# Altium schematic sheet [03] - 28PINS SCHEMATIC.SchDoc("[03] - 28PINS SCHEMATIC") (continued)

## Library data of the parts
C1 C2 = 875105242010: WCAP-PSLP Aluminum Polymer Capacitors; Manufacturer: Wurth Electronics; Dissipation Factor: 8%; Leakage Current: 300uA; Material: 4242ConductorMaterial; Pitch: 5.3mm; Voltage Rating (DC): 10V; Category: Polymer Capacitors; Component Kind: Standard; ComponentLink1Description: http://www.farnell.com/datasheets/1880491.pdf?src-supplier=Farnell; ComponentLink1URL: http://www.farnell.com/datasheets/1880491.pdf?src-supplier=Farnell; ComponentLink2Description: http://katalog.we-online.de/pbs/datasheet/875105242010.pdf?src-supplier=Digikey; ComponentLink2URL: http://katalog.we-online.de/pbs/datasheet/875105242010.pdf?src-supplier=Digikey; ComponentLink3Description: https://katalog.we-online.de/pbs/datasheet/875105242010.pdf?src-supplier=Digikey; ComponentLink3URL: https://katalog.we-online.de/pbs/datasheet/875105242010.pdf?src-supplier=Digikey; ComponentLink4Description: http://www.farnell.com/datasheets/1880491.pdf?src-supplier=Newark; ComponentLink4URL: http://www.farnell.com/datasheets/1880491.pdf?src-supplier=Newark; ComponentLink5Description: http://www.farnell.com/datasheets/1880491.pdf?src-supplier=Element14; ComponentLink5URL: http://www.farnell.com/datasheets/1880491.pdf?src-supplier=Element14; Diameter: 5mm; Height: 5.5mm; Length: 5.5mm; Library Name: Schlib1.SchLib; Manufacturer Part Number: 875105242010; Pricing 1: 1=0.54, 50=0.4572, 100=0.3969, 250=0.3528, 500=0.3087 (USD); RoHS: Yes; Stock 1: 10012; Supplier 1: Digikey; Supplier Part Number 1: 732-6410-1-ND
C3 C12 C13 C19 C21 C22 C34 = CL21A106KOQNNNE: Multilayer Ceramic Capacitor, 10 uF, 16 V, ± 10%, X5R, 0805 [2012 Metric]; Manufacturer: Samsung; Dielectric: X7R; Value: 10uF; Voltage: 16V; Case Code (Imperial): 0805; Case Code (Metric): 2012; Case/Package: 0805; Category: Ceramic Capacitors; Component Kind: Standard; ComponentLink1Description: https://datasheet.ciiva.com/25798/cl21a106koqnnne-25798133.pdf?src-supplier=Digikey; ComponentLink1URL: https://datasheet.ciiva.com/25798/cl21a106koqnnne-25798133.pdf?src-supplier=Digikey; ComponentLink2Description: https://datasheet.ciiva.com/15216/getdatasheetpartid-358435-15216234.pdf?src-supplier=Verical; ComponentLink2URL: https://datasheet.ciiva.com/15216/getdatasheetpartid-358435-15216234.pdf?src-supplier=Verical; ComponentLink3Description: https://datasheet.ciiva.com/16740/cl05b104kq5nnnc-16740697.pdf?src-supplier=Future+Electronics; ComponentLink3URL: https://datasheet.ciiva.com/16740/cl05b104kq5nnnc-16740697.pdf?src-supplier=Future+Electronics; Construction: Flat; Depth: 1.25mm; Height: 1.25mm; Lead Free: Lead Free; Length: 2mm; Library Name: Schlib1.SchLib; Manufacturer Part Number: CL21A106KOQNNNE; Material: Ceramic; Max Operating Temperature: 85°C; Min Operating Temperature: -55°C; Mounting Technology: Surface Mount; Package Quantity: 1; Packaging: Tape and Reel; Pricing 1: 1=0.08, 10=0.027, 50=0.0224, 100=0.0176, 500=0.0164, 1000=0.0152 (USD); Radiation Hardening: No; RoHS: Yes; RoHS Compliant: Yes; Series: CL; Stock 1: 2043437; Supplier 1: Digikey; Supplier Part Number 1: 1276-1096-1-ND; Thickness: 0.055inch; Tolerance: 10%; Voltage Rating: 16V; Voltage Rating (DC): 16V; Width: 0.049inch
C4-C6 C8 C11 C14-C17 C20 C23 C24 C26 C28-C33 = CGA3E2X7R1H104K080AA: Multilayer Ceramic Capacitor, 0.1 uF, 50 V, ï¿½ 10%, X7R, 0603 [1608 Metric]; Manufacturer: TDK; Material: 4241ConductorMaterial; Voltage: 50V; Case Code (Imperial): 0603; Case Code (Metric): 1608; Case/Package: 0603; Category: Ceramic Capacitors; Component Kind: Standard; ComponentLink1Description: https://datasheet.ciiva.com/24216/cga3e2x7r1h104k080aa-266291-24216208.pdf?src-supplier=Mouser; ComponentLink1URL: https://datasheet.ciiva.com/24216/cga3e2x7r1h104k080aa-266291-24216208.pdf?src-supplier=Mouser; ComponentLink2Description: https://datasheet.ciiva.com/15192/getdatasheetpartid-715867-15192412.pdf?src-supplier=Verical; ComponentLink2URL: https://datasheet.ciiva.com/15192/getdatasheetpartid-715867-15192412.pdf?src-supplier=Verical; ComponentLink3Description: https://datasheet.ciiva.com/22725/1735392-22725642.pdf?src-supplier=Element14; ComponentLink3URL: https://datasheet.ciiva.com/22725/1735392-22725642.pdf?src-supplier=Element14; ComponentLink4Description: https://datasheet.ciiva.com/46385/1735392-46385708.pdf?src-supplier=Element14; ComponentLink4URL: https://datasheet.ciiva.com/46385/1735392-46385708.pdf?src-supplier=Element14; ComponentLink5Description: https://datasheet.ciiva.com/23803/1936565-23803084.pdf?src-supplier=Newark; ComponentLink5URL: https://datasheet.ciiva.com/23803/1936565-23803084.pdf?src-supplier=Newark; ComponentLink6Description: https://datasheet.ciiva.com/16500/mlccspec-automotive-general-midvoltage-en-16500693.pdf?src-supplier=Future+Electronics; ComponentLink6URL: https://datasheet.ciiva.com/16500/mlccspec-automotive-general-midvoltage-en-16500693.pdf?src-supplier=Future+Electronics; Depth: 0.8mm; Dielectric: X7R; Height: 0.8mm; Lead Free: 5697LeadFree; Length: 1.6mm; Library Name: Schlib1.SchLib; Manufacturer Part Number: CGA3E2X7R1H104K080AA; Max Operating Temperature: 125°C; Min Operating Temperature: -55°C; Mounting Technology: Surface Mount; Package Quantity: 1; Packaging: Tape and Reel; Pricing 1: 1=0.1, 10=0.048, 50=0.033, 100=0.0284, 500=0.02046, 1000=0.01801 (USD); Radiation Hardening: No; Ratings: AEC-Q200; Reach SVHC Compliant: No; RoHS: Yes; RoHS Compliant: Yes; Series: CGA; Stock 1: 2978211; Supplier 1: Digikey; Supplier Part Number 1: 445-5666-1-ND; Termination: SMD/SMT; Thickness: 0.035inch; Tolerance: 10%; Value: 100nF; Voltage Rating: 50V; Voltage Rating (DC): 50V; Weight: 7.1E-05oz; Width: 0.031inch
C7 = C1005X5R1E105K050BC: Multilayer Ceramic Capacitor, 1 uF, 25 V, ï¿½ 10%, X5R, 0402 [1005 Metric]; Manufacturer: TDK; Dielectric Material: 4241ConductorMaterial; Voltage Rating: 25V; Case Code (Imperial): 0402; Case Code (Metric): 1005; Case/Package: 0402; Category: Ceramic Capacitors; Component Kind: Standard; ComponentLink1Description: https://datasheet.ciiva.com/26936/getdatasheetpartid-756138-26936447.pdf?src-supplier=Verical; ComponentLink1URL: https://datasheet.ciiva.com/26936/getdatasheetpartid-756138-26936447.pdf?src-supplier=Verical; ComponentLink2Description: https://datasheet.ciiva.com/22719/1796654-22719532.pdf?src-supplier=Element14; ComponentLink2URL: https://datasheet.ciiva.com/22719/1796654-22719532.pdf?src-supplier=Element14; Depth: 0.5mm; Dielectric: X5R; Lead Free: 5697LeadFree; Length: 1mm; Library Name: Schlib1.SchLib; Manufacturer Part Number: C1005X5R1E105K050BC; Material: 4241ConductorMaterial; Max Operating Temperature: 85°C; Min Operating Temperature: -55°C; Mounting Technology: Surface Mount; Package Quantity: 1; Packaging: Tape and Reel; Pricing 1: 1=0.1, 10=0.054, 50=0.0374, 100=0.0322, 500=0.0233, 1000=0.02054, 2500=0.01762, 5000=0.01586 (USD); Radiation Hardening: No; Reach SVHC Compliant: No; RoHS: Yes; RoHS Compliant: Yes; Series: C; Stock 1: 435193; Supplier 1: Digikey; Supplier Part Number 1: 445-9066-1-ND; Thickness: 0.022inch; Tolerance: 10%; Value: 1uF; Width: 0.02inch
C9 C10 C25 C27 = C0805C180K5GACTU: Multilayer Ceramic Capacitors MLCC - SMD/SMT 50volts 18pF C0G 10%; Manufacturer: KEMET; Dielectric Material: 4241ConductorMaterial; Temperature Coefficient: 30ppm/°C; Category: Ceramic Capacitors; Component Kind: Standard; ComponentLink1Description: https://datasheet.ciiva.com/5412/kem-c1003-c0g-smd-356956-5412921.pdf?src-supplier=Mouser; ComponentLink1URL: https://datasheet.ciiva.com/5412/kem-c1003-c0g-smd-356956-5412921.pdf?src-supplier=Mouser; ComponentLink2Description: https://datasheet.ciiva.com/26847/getdatasheetpartid-742486-26847863.pdf?src-supplier=Verical; ComponentLink2URL: https://datasheet.ciiva.com/26847/getdatasheetpartid-742486-26847863.pdf?src-supplier=Verical; Lead Free: 5697LeadFree; Library Name: Schlib1.SchLib; Manufacturer Part Number: C0805C180K5GACTU; Material: 4241ConductorMaterial; Pricing 1: 1=0.1, 10=0.035, 50=0.035, 100=0.022, 1000=0.018, 10000=0.012 (USD); RoHS: Yes; Stock 1: 26678; Supplier 1: Mouser; Supplier Part Number 1: 80-C0805C180K5G
D1 = LGL29K-G2J1-24-Z: LED Uni-Color Green 572nm Automotive 2-Pin Chip 0603(1608Metric) T/R; Manufacturer: Osram Opto; Dominant Wavelength: 570nm; Forward Current: 20mA; Forward Voltage: 2.2V; Lens Color: White; Lens Size: 0.8mm; Lens Style: Flat,Diffused,Colorless,Rectangular; Lens Transparency: Diffused; Luminous Flux: 0.012lm; Luminous Intensity: 5.6mcd; Number of Elements: 1; Reverse Voltage: 12V; Test Current: 2mA; Viewing Angle: 160°; Case Code (Imperial): 0603; Case Code (Metric): 1608; Case/Package: 0603; Category: LEDs; Color: Green; Component Kind: Standard; ComponentLink1Description: https://datasheet.ciiva.com/4689/g-l29k-39844-4689584.pdf?src-supplier=Mouser; ComponentLink1URL: https://datasheet.ciiva.com/4689/g-l29k-39844-4689584.pdf?src-supplier=Mouser; ComponentLink2Description: https://datasheet.ciiva.com/2112/00077107-0-2112887.pdf?src-supplier=Digikey; ComponentLink2URL: https://datasheet.ciiva.com/2112/00077107-0-2112887.pdf?src-supplier=Digikey; ComponentLink3Description: https://datasheet.ciiva.com/2112/00077107-0-2112886.pdf?src-supplier=Digikey; ComponentLink3URL: https://datasheet.ciiva.com/2112/00077107-0-2112886.pdf?src-supplier=Digikey; ComponentLink4Description: https://datasheet.ciiva.com/2112/00077107-0-2112888.pdf?src-supplier=Digikey; ComponentLink4URL: https://datasheet.ciiva.com/2112/00077107-0-2112888.pdf?src-supplier=Digikey; Depth: 0.8mm; Height: 0.65mm; Illumination Color: Green; Length: 1.3mm; Library Name: Schlib1.SchLib; Manufacturer Part Number: LGL29K-G2J1-24-Z; Max Operating Temperature: 100°C; Min Operating Temperature: -40°C; Mounting Technology: Surface Mount; Number of LEDs: 1; Package Quantity: 5000; Packaging: Tape and Reel; Pins: 2; Pricing 1: 5000=0.0793, 10000=0.0742, 15000=0.0714, 20000=0.0705, 25000=0.0659, 50000=0.0614 (USD); Radiation Hardening: No; RoHS: Yes; RoHS Compliant: Yes; Series: SMARTLED® 0603; Stock 1: 75000; Supplier 1: Arrow Electronics; Supplier Part Number 1: LGL29K-G2J1-24-Z; Wavelength: 570nm; Width: 0.8mm
D2-D4 = SML-311DTT86: LED Uni-Color Orange 2-Pin Chip 0603(1608Metric) T/R; Manufacturer: Rohm; Category: LEDs; Component Kind: Standard; ComponentLink1Description: https://datasheet.ciiva.com/11774/0900766b80df3a77-11774225.pdf?src-supplier=Rs; ComponentLink1URL: https://datasheet.ciiva.com/11774/0900766b80df3a77-11774225.pdf?src-supplier=Rs; ComponentLink2Description: https://datasheet.ciiva.com/2110/sml-311series-2110838.pdf?src-supplier=Digikey; ComponentLink2URL: https://datasheet.ciiva.com/2110/sml-311series-2110838.pdf?src-supplier=Digikey; ComponentLink3Description: https://datasheet.ciiva.com/2110/sml-311series-2110839.pdf?src-supplier=Digikey; ComponentLink3URL: https://datasheet.ciiva.com/2110/sml-311series-2110839.pdf?src-supplier=Digikey; ComponentLink4Description: https://datasheet.ciiva.com/2110/sml-311series-2110840.pdf?src-supplier=Digikey; ComponentLink4URL: https://datasheet.ciiva.com/2110/sml-311series-2110840.pdf?src-supplier=Digikey; ComponentLink5Description: https://datasheet.ciiva.com/16388/sml-31-16388237.pdf?src-supplier=Future+Electronics; ComponentLink5URL: https://datasheet.ciiva.com/16388/sml-31-16388237.pdf?src-supplier=Future+Electronics; Library Name: Schlib1.SchLib; Manufacturer Part Number: SML-311DTT86; Pricing 1: 1=0.4, 10=0.226, 100=0.1416, 500=0.10852, 1000=0.09839 (USD); RoHS: Yes; Stock 1: 22355; Supplier 1: Digikey; Supplier Part Number 1: 846-SML-311DTT86CT-ND
D5 D6 = BAT54HT1G: Small Signal Schottky Diode, Single, 30 V, 200 Ma, 800 Mv, 600 Ma, 150 C; Manufacturer: ON Semiconductor / Fairchild; Average Rectified Current: 200mA; Breakdown Voltage: 30V; Forward Voltage: 800mV; Max Forward Surge Current (Ifsm): 600mA; Max Reverse Current: 2uA; Polarity: 4901Direction; Power Dissipation: 200mW; Recovery Time: 5ns; Value: 10pF; Category: Schottky Diodes; Component Kind: Standard; ComponentLink1Description: https://datasheet.ciiva.com/4714/bat54ht1-d-72881-4714706.pdf?src-supplier=Mouser; ComponentLink1URL: https://datasheet.ciiva.com/4714/bat54ht1-d-72881-4714706.pdf?src-supplier=Mouser; ComponentLink2Description: https://datasheet.ciiva.com/4452/bat54ht1g-104602-4452889.pdf?src-supplier=Mouser; ComponentLink2URL: https://datasheet.ciiva.com/4452/bat54ht1g-104602-4452889.pdf?src-supplier=Mouser; ComponentLink3Description: https://datasheet.ciiva.com/30479/0900766b811a63a9-30479442.pdf?src-supplier=Rs; ComponentLink3URL: https://datasheet.ciiva.com/30479/0900766b811a63a9-30479442.pdf?src-supplier=Rs; ComponentLink4Description: https://datasheet.ciiva.com/34301/806549-34301562.pdf?src-supplier=Jameco; ComponentLink4URL: https://datasheet.ciiva.com/34301/806549-34301562.pdf?src-supplier=Jameco; ComponentLink5Description: https://datasheet.ciiva.com/1240/1747983-1240762.pdf?src-supplier=Newark; ComponentLink5URL: https://datasheet.ciiva.com/1240/1747983-1240762.pdf?src-supplier=Newark; ComponentLink6Description: https://datasheet.ciiva.com/915/bat54ht1-d-915445.pdf?src-supplier=Digikey; ComponentLink6URL: https://datasheet.ciiva.com/915/bat54ht1-d-915445.pdf?src-supplier=Digikey; ComponentLink7Description: https://datasheet.ciiva.com/915/bat54ht1-d-915444.pdf?src-supplier=Digikey; ComponentLink7URL: https://datasheet.ciiva.com/915/bat54ht1-d-915444.pdf?src-supplier=Digikey; ComponentLink8Description: https://datasheet.ciiva.com/910/bat54ht1g-910499.pdf?src-supplier=Digikey; ComponentLink8URL: https://datasheet.ciiva.com/910/bat54ht1g-910499.pdf?src-supplier=Digikey; ComponentLink9Description: https://datasheet.ciiva.com/910/bat54ht1g-910498.pdf?src-supplier=Digikey; ComponentLink9URL: https://datasheet.ciiva.com/910/bat54ht1g-910498.pdf?src-supplier=Digikey; ComponentLink10Description: https://datasheet.ciiva.com/8294/bat54ht1-d-8294645.pdf?src-supplier=Avnet; ComponentLink10URL: https://datasheet.ciiva.com/8294/bat54ht1-d-8294645.pdf?src-supplier=Avnet; ComponentLink11Description: https://datasheet.ciiva.com/910/bat54ht1g-910497.pdf?src-supplier=Digikey; ComponentLink11URL: https://datasheet.ciiva.com/910/bat54ht1g-910497.pdf?src-supplier=Digikey; ComponentLink12Description: https://datasheet.ciiva.com/8303/bat54ht1g-8303645.pdf?src-supplier=Avnet; ComponentLink12URL: https://datasheet.ciiva.com/8303/bat54ht1g-8303645.pdf?src-supplier=Avnet; ComponentLink13Description: https://datasheet.ciiva.com/915/bat54ht1-d-915443.pdf?src-supplier=Digikey; ComponentLink13URL: https://datasheet.ciiva.com/915/bat54ht1-d-915443.pdf?src-supplier=Digikey; ComponentLink14Description: https://datasheet.ciiva.com/46429/1727620-46429206.pdf?src-supplier=Element14; ComponentLink14URL: https://datasheet.ciiva.com/46429/1727620-46429206.pdf?src-supplier=Element14; ComponentLink15Description: https://datasheet.ciiva.com/1180/bat54ht1-d-1180252.pdf?src-supplier=Element14; ComponentLink15URL: https://datasheet.ciiva.com/1180/bat54ht1-d-1180252.pdf?src-supplier=Element14; ComponentLink16Description: https://datasheet.ciiva.com/1180/bat54ht1-d-1180251.pdf?src-supplier=Element14; ComponentLink16URL: https://datasheet.ciiva.com/1180/bat54ht1-d-1180251.pdf?src-supplier=Element14; ComponentLink17Description: https://datasheet.ciiva.com/17020/bat54ht1g-17020445.pdf?src-supplier=Future+Electronics; ComponentLink17URL: https://datasheet.ciiva.com/17020/bat54ht1g-17020445.pdf?src-supplier=Future+Electronics; ComponentLink18Description: https://datasheet.ciiva.com/48686/001262925-da-01-en-diode-schottky-30v-bat54ht1g-sod-323-fsc-48686266.pdf?src-supplier=Conrad; ComponentLink18URL: https://datasheet.ciiva.com/48686/001262925-da-01-en-diode-schottky-30v-bat54ht1g-sod-323-fsc-48686266.pdf?src-supplier=Conrad; Contact Plating: Tin,Matte; Current Rating: 200mA; Forward Current: 200mA; Height: 1mm; Lead Free: Lead Free; Length: 1.8mm; Library Name: Schlib1.SchLib; Manufacturer Part Number: BAT54HT1G; Max Operating Temperature: 150°C; Max Repetitive Reverse Voltage (Vrrm): 30V; Max Reverse Leakage Current: 2uA; Max Reverse Voltage (DC): 30V; Max Surge Current: 600mA; Min Operating Temperature: -55°C; Mounting Technology: Surface Mount; Output Current: 200mA; Package Quantity: 1; Packaging: Tape and Reel; Peak Non-Repetitive Surge Current: 600mA; Pins: 2; Pricing 1: 3000=0.0119, 12000=0.0119, 30000=0.0119, 75000=0.0119, 120000=0.0119 (USD); Radiation Hardening: No; Reach SVHC Compliant: No; Reverse Recovery Time: 5ns; Reverse Recovery Time-Max: 5ns; Reverse Voltage: 30V; RoHS: Yes; RoHS Compliant: Yes; Series: -; Stock 1: 2241000; Supplier 1: Future Electronics; Supplier Part Number 1: 8184713; Voltage Rating (DC): 30V; Width: 1.35mm
FB1-FB3 = MPZ2012S601AT000: Ferrite Beads Multi-Layer Power 600Ohm 25% 100MHz 2A 100mOhm DCR 0805 T/R; Manufacturer: TDK; Core Material: 228ConductorMaterial; DC Resistance (DCR): 100mR; Impedance: 600R; Number of Lines: 1; Case Code (Imperial): 0805; Case Code (Metric): 2012; Case/Package: 0805; Category: Ferrite Beads and Chips; Component Kind: Standard; ComponentLink1Description: https://datasheet.ciiva.com/46634/beads-commercial-power-mpz-en-46634335.pdf?src-supplier=Digikey; ComponentLink1URL: https://datasheet.ciiva.com/46634/beads-commercial-power-mpz-en-46634335.pdf?src-supplier=Digikey; ComponentLink2Description: https://datasheet.ciiva.com/46634/beads-commercial-power-mpz-en-46634377.pdf?src-supplier=Digikey; ComponentLink2URL: https://datasheet.ciiva.com/46634/beads-commercial-power-mpz-en-46634377.pdf?src-supplier=Digikey; ComponentLink3Description: https://datasheet.ciiva.com/46634/beads-commercial-power-mpz-en-46634606.pdf?src-supplier=Digikey; ComponentLink3URL: https://datasheet.ciiva.com/46634/beads-commercial-power-mpz-en-46634606.pdf?src-supplier=Digikey; Current Rating: 2A; ESR: 100mR; Height: 0.041inch; Lead Free: Lead Free; Length: 0.079inch; Library Name: Schlib1.SchLib; Manufacturer Part Number: MPZ2012S601AT000; Max Current Rating: 2A; Max DC Current: 2A; Max Operating Temperature: 125°C; Min Operating Temperature: -55°C; Mounting Technology: Surface Mount; Package Quantity: 1; Packaging: Tape and Reel; Pricing 1: 1=0.11, 10=0.077, 25=0.0664, 50=0.0594, 100=0.0532, 250=0.0458, 500=0.0407, 1000=0.03605 (USD); Reach SVHC Compliant: No; RMS Current (Irms): 2A; RoHS: Yes; RoHS Compliant: Yes; Series: MPZ; Stock 1: 117452; Supplier 1: Digikey; Supplier Part Number 1: 445-MPZ2012S601AT000CT-ND; Test Frequency: 100MHz; Tolerance: 25%; Width: 0.049inch
FID1-FID4 = FID_1MM: Fiducial 40mil diameter,120mil mask; Library Name: Schlib1.SchLib
J1 J3 = PPTC081LFBN-RC: Female, 8C, Straight, .100"CC; 3.20mm Tail, No Mt. 100u" Tin; PBT, Phos Bronze, 105 C; Manufacturer: Sullins; Category: Connectors; Component Kind: Standard; ComponentLink1Description: https://media.digikey.com/pdf/Data%20Sheets/Sullins%20PDFs/Female_Headers.100_DS.pdf?src-supplier=Digikey; ComponentLink1URL: https://media.digikey.com/pdf/Data%20Sheets/Sullins%20PDFs/Female_Headers.100_DS.pdf?src-supplier=Digikey; ComponentLink2Description: https://datasheet.octopart.com/PPTC081LFBN-RC-Sullins-datasheet-41308905.pdf?src-supplier=Future+Electronics; ComponentLink2URL: https://datasheet.octopart.com/PPTC081LFBN-RC-Sullins-datasheet-41308905.pdf?src-supplier=Future+Electronics; ComponentLink3Description: http://www.sullinscorp.com/catalogs/78_Page80-81_HEADER_.100_2.54mm_FEMALE.pdf?src-supplier=Future+Electronics; ComponentLink3URL: http://www.sullinscorp.com/catalogs/78_Page80-81_HEADER_.100_2.54mm_FEMALE.pdf?src-supplier=Future+Electronics; Contact Material: 150ConductorMaterial; Height: 8.5mm; Housing Material: 4239ConductorMaterial; Lead Free: 5697LeadFree; Length: 20.8mm; Library Name: Schlib1.SchLib; Manufacturer Part Number: PPTC081LFBN-RC; Pricing 1: 1=0.56, 10=0.457, 400=0.32898, 800=0.30935, 1200=0.29843, 5200=0.2925 (USD); RoHS: Yes; Stock 1: 22644; Supplier 1: Digikey; Supplier Part Number 1: S7006-ND; Width: 2.5mm
J2 = PPTC061LFBN-RC: Female, 6 C, Straight, .100"CC; 3.20mm Tail, No Mt. 100u" Tin; PBT, Phos Bronze, 105 C; Manufacturer: Sullins; Category: Connectors; Component Kind: Standard; ComponentLink1Description: https://media.digikey.com/pdf/Data%20Sheets/Sullins%20PDFs/Female_Headers.100_DS.pdf?src-supplier=Digikey; ComponentLink1URL: https://media.digikey.com/pdf/Data%20Sheets/Sullins%20PDFs/Female_Headers.100_DS.pdf?src-supplier=Digikey; ComponentLink2Description: https://datasheet.octopart.com/PPTC061LFBN-RC-Sullins-datasheet-41308905.pdf?src-supplier=Future+Electronics; ComponentLink2URL: https://datasheet.octopart.com/PPTC061LFBN-RC-Sullins-datasheet-41308905.pdf?src-supplier=Future+Electronics; ComponentLink3Description: http://www.sullinscorp.com/catalogs/78_Page80-81_HEADER_.100_2.54mm_FEMALE.pdf?src-supplier=Future+Electronics; ComponentLink3URL: http://www.sullinscorp.com/catalogs/78_Page80-81_HEADER_.100_2.54mm_FEMALE.pdf?src-supplier=Future+Electronics; Library Name: Schlib1.SchLib; Manufacturer Part Number: PPTC061LFBN-RC; Pricing 1: 1=0.45, 10=0.37, 80=0.30788, 440=0.26464, 1000=0.24605, 5000=0.2327 (USD); RoHS: Yes; Stock 1: 17823; Supplier 1: Digikey
J4 = PPTC101LFBN-RC: 10 Position Single Row 2.54 mm Pitch Vertical Through Hole Socket Header; Manufacturer: Sullins; Category: Connectors; Component Kind: Standard; ComponentLink1Description: https://media.digikey.com/pdf/Data%20Sheets/Sullins%20PDFs/Female_Headers.100_DS.pdf?src-supplier=Digikey; ComponentLink1URL: https://media.digikey.com/pdf/Data%20Sheets/Sullins%20PDFs/Female_Headers.100_DS.pdf?src-supplier=Digikey; Library Name: Schlib1.SchLib; Manufacturer Part Number: PPTC101LFBN-RC; Pricing 1: 1=0.62, 10=0.503, 320=0.36947, 640=0.34744, 960=0.33517, 5120=0.325 (USD); RoHS: Yes; Stock 1: 14684; Supplier 1: Digikey; Supplier Part Number 1: S7008-ND
J5 J6 = M20-9980346: 2.54mm (0.1") Pitch DIL Vertical PC Tail Pin Header, 6.1mm mating pin height, tin, 3+3 contacts; Manufacturer: Harwin; Gender: 29Gender; Insulation Resistance: 10GR; Voltage Rating (DC): 750V; Category: Headers and Wire Housings; Component Kind: Standard; ComponentLink1Description: https://datasheet.ciiva.com/26899/getdatasheetpartid-483857-26899433.pdf?src-supplier=Verical; ComponentLink1URL: https://datasheet.ciiva.com/26899/getdatasheetpartid-483857-26899433.pdf?src-supplier=Verical; ComponentLink2Description: https://datasheet.ciiva.com/11745/0900766b81034e61-11745752.pdf?src-supplier=Rs; ComponentLink2URL: https://datasheet.ciiva.com/11745/0900766b81034e61-11745752.pdf?src-supplier=Rs; ComponentLink3Description: https://datasheet.ciiva.com/8046/112195-8046906.pdf?src-supplier=Element14; ComponentLink3URL: https://datasheet.ciiva.com/8046/112195-8046906.pdf?src-supplier=Element14; Library Name: Schlib1.SchLib; Manufacturer Part Number: M20-9980346; Pricing 1: 1=0.24, 10=0.197, 100=0.1606, 500=0.13922, 1000=0.13092, 5000=0.1135, 10000=0.10674 (USD); RoHS: Yes; Stock 1: 6432; Supplier 1: Digikey; Supplier Part Number 1: 952-2121-ND
J7 = 10118193-0001LF: USB - micro B USB 2.0 Receptacle Connector 5 Position Surface Mount, Right Angle, Horizontal; Manufacturer: Amphenol Communications Solutions; Connector Type: 309,4640ConnectorType; Current Rating: 1.8A; Insulation Resistance: 1GR; Max Voltage Rating (AC): 100V; Number of Contacts: 5NUMBER; Number of Ports: 1NUMBER; Pitch: 650um; USB Standard: 6108USBStandard; Category: USB Connectors; Color: 46Colour; Component Kind: Standard; ComponentLink1Description: https://datasheet.octopart.com/10118193-0001LF-Amphenol-Communications-Solutions-datasheet-96019471.pdf?src-supplier=Element14; ComponentLink1URL: https://datasheet.octopart.com/10118193-0001LF-Amphenol-Communications-Solutions-datasheet-96019471.pdf?src-supplier=Element14; ComponentLink2Description: https://datasheet.octopart.com/10118193-0001LF-Amphenol-Communications-Solutions-datasheet-55953155.pdf?src-supplier=TME; ComponentLink2URL: https://datasheet.octopart.com/10118193-0001LF-Amphenol-Communications-Solutions-datasheet-55953155.pdf?src-supplier=TME; ComponentLink3Description: https://datasheet.octopart.com/10118193-0001LF-Amphenol-Communications-Solutions-datasheet-11792339.pdf?src-supplier=Electro+Sonic; ComponentLink3URL: https://datasheet.octopart.com/10118193-0001LF-Amphenol-Communications-Solutions-datasheet-11792339.pdf?src-supplier=Electro+Sonic; Contact Material: 153,157ConductorMaterial; Contact Plating: 157ConductorMaterial; Depth: 5.67mm; Features: 6303FeaturesType; Flammability Rating: 5813Flammability; Height: 3mm; Housing Material: 4242ConductorMaterial; Length: 7.86mm; Library Name: Schlib1.SchLib; Manufacturer Part Number: 10118193-0001LF; Max Operating Temperature: 80°C; Min Operating Temperature: -55°C; Mounting Technology: 8,4608Mount; Orientation: 5492OrientationType; Pins: 11NUMBER; Pricing 1: 1=0.4, 10=0.326, 25=0.3, 50=0.2822, 100=0.2654, 250=0.24464, 500=0.23002 (USD); Radiation Hardening: 5898YesNo; RoHS: No; Stock 1: 168770; Supplier 1: Digikey; Supplier Part Number 1: 609-4616-1-ND; Termination: 4558ConnectorType; Voltage Rating: 100V
J8 J9 = PAD_WITH_HOLE_4MMX1.5MM: pad with a hall 4mmx1.5mm; Library Name: Schlib1.SchLib
JP1 JP4 = M20-9990345: Conn Unshrouded Header HDR 3 POS 2.54mm Solder ST Top Entry Thru-Hole Bag; Manufacturer: Harwin; Contact Resistance: 25mR; Current Rating: 3A; Insulation Resistance: 10GR; Number of Contacts: 3; Voltage Rating (AC): 750V; Case/Package: SIL; Category: Headers and Wire Housings; Color: Black; Component Kind: Standard; ComponentLink1Description: https://datasheet.ciiva.com/11747/0900766b80da602d-11747505.pdf?src-supplier=Rs; ComponentLink1URL: https://datasheet.ciiva.com/11747/0900766b80da602d-11747505.pdf?src-supplier=Rs; ComponentLink2Description: https://datasheet.ciiva.com/5160/m20-999-351179-5160492.pdf?src-supplier=Mouser; ComponentLink2URL: https://datasheet.ciiva.com/5160/m20-999-351179-5160492.pdf?src-supplier=Mouser; ComponentLink3Description: https://datasheet.ciiva.com/10151/m20-999-10151334.pdf?src-supplier=Avnet; ComponentLink3URL: https://datasheet.ciiva.com/10151/m20-999-10151334.pdf?src-supplier=Avnet; Contact Material: Bronze,Brass; Contact Mating Length: 0.24inch; Contact Plating: Gold; Contact Type: Male Pin; Depth: 2.54mm; Housing Color: Black; Housing Material: Nylon; Length: 7.62mm; Library Name: Schlib1.SchLib; Manufacturer Part Number: M20-9990345; Max Current Rating: 3A; Max Operating Temperature: 105°C; Min Operating Temperature: -40°C; Mounting Technology: Through Hole; Number of Positions: 3; Number of Rows: 1; Orientation: Straight; Package Quantity: 1000; Packaging: Bulk; Pitch: 2.54mm; Pricing 1: 1=0.15, 10=0.119, 100=0.0969, 500=0.08394, 1000=0.07893, 5000=0.06843, 10000=0.06435 (USD); Radiation Hardening: No; Reach SVHC Compliant: No; RoHS: Yes; RoHS Compliant: Yes; Series: M20; Stock 1: 90259; Supplier 1: Digikey; Supplier Part Number 1: 952-2263-ND; Termination: Solder; Weight: 0.006138oz
JP2 = HTSW-102-07-G-D: Conn Unshrouded Header HDR 4 POS 2.54mm Solder ST Top Entry Thru-Hole Bag; Manufacturer: Samtec; Gender: Male; Number of Contacts: 4; Pitch: 0.1inch; Category: Headers and Wire Housings; Color: Natural; Component Kind: Standard; ComponentLink1Description: https://datasheet.ciiva.com/737/htsw-xxx-xx-xxx-x-xx-xx-xx-mkt-737892.pdf?src-supplier=Digikey; ComponentLink1URL: https://datasheet.ciiva.com/737/htsw-xxx-xx-xxx-x-xx-xx-xx-mkt-737892.pdf?src-supplier=Digikey; ComponentLink2Description: https://datasheet.ciiva.com/10178/htsw-th-10178927.pdf?src-supplier=Avnet; ComponentLink2URL: https://datasheet.ciiva.com/10178/htsw-th-10178927.pdf?src-supplier=Avnet; Contact Material: Bronze; Contact Mating Length: 0.23inch; Contact Plating: Gold; Contact Type: Male Pin; Library Name: Schlib1.SchLib; Manufacturer Part Number: HTSW-102-07-G-D; Mounting Technology: Through Hole; Number of Positions: 4; Number of Rows: 2; Package Quantity: 1; Packaging: Bulk; Pricing 1: 1=0.27, 10=0.22, 100=0.1789, 500=0.15506, 1000=0.1458, 5000=0.12641, 10000=0.11888 (USD); Radiation Hardening: No; Reach SVHC Compliant: No; RoHS: Yes; RoHS Compliant: Yes; Row Spacing: 0.1inch; Series: HTSW; Stock 1: 776; Supplier 1: Digikey; Supplier Part Number 1: SAM10533-ND; Termination: Solder
JP3 = M20-9770446: Conn Unshrouded Header HDR 4 POS 2.54mm Solder ST Thru-Hole Bulk/Loose; Manufacturer: Harwin; Current Rating: 3A; Gender: Male; Mated Stacking Heights: 16.54mm; Number of Contacts: 4; Voltage Rating: 750V; Case/Package: SIL; Category: Headers and Wire Housings; Component Kind: Standard; ComponentLink1Description: https://datasheet.ciiva.com/6832/m20-977-6832936.pdf?src-supplier=Digikey; ComponentLink1URL: https://datasheet.ciiva.com/6832/m20-977-6832936.pdf?src-supplier=Digikey; Contact Finish - Mating: TIN; Contact Material: Brass; Contact Plating: Tin; Contact Shape: Square; Contact Type: Male Pin; Fastening Type: Pull; Flammability Rating: UL94 V-0; Housing Material: Nylon; Insulation Color: Black; Insulation Material: Nylon; Library Name: Schlib1.SchLib; Manufacturer Part Number: M20-9770446; Max Operating Temperature: 105°C; Min Operating Temperature: -40°C; Mounting Technology: Through Hole; Number of Positions: 4; Number of Rows: 1; Package Quantity: 1000; Packaging: Bulk; Pitch: 2.54mm; Pricing 1: 1=0.17, 10=0.14, 100=0.114, 500=0.09884, 1000=0.09294, 5000=0.08058, 10000=0.07578 (USD); RoHS: Yes; RoHS Compliant: Yes; Series: M20; Stock 1: 9094; Supplier 1: Digikey; Supplier Part Number 1: 952-1785-ND; Termination: Solder
L1 = CIGT252008LM2R2MNE: ROHS3Compliant Thin Film Active WRAPAROUND Fixed Inductor -40C~125C 1MHz 97mOhm Max 2.2muH; Manufacturer: Samsung; Core Material: Metal,Composite; DC Resistance (DCR): 97mR; Saturation Current: 2.1A; Shielding: Unshielded; Tolerance: 20%; Value: 2.2uH; Case Code (Imperial): 1008; Case Code (Metric): 2520; Case/Package: 1008; Category: Fixed Inductors; Component Kind: Standard; ComponentLink1Description: http://www.samsungsem.com/kr/support/product-search/inductor/CIGT252008LM2R2MNE.jsp?src-supplier=Digikey; ComponentLink1URL: http://www.samsungsem.com/kr/support/product-search/inductor/CIGT252008LM2R2MNE.jsp?src-supplier=Digikey; Library Name: Schlib1.SchLib; Manufacturer Part Number: CIGT252008LM2R2MNE; Max Operating Temperature: 125°C; Min Operating Temperature: -40°C; Mounting Technology: Surface Mount; Packaging: Cut Tape; Pricing 1: 1=0.23, 10=0.176, 25=0.1592, 50=0.1476, 100=0.1368, 250=0.12368, 500=0.11458, 1000=0.10614 (USD); RoHS: Yes; RoHS Compliant: Yes; Series: CIGT; Stock 1: 197003; Supplier 1: Digikey; Supplier Part Number 1: 1276-6925-1-ND; Test Frequency: 1MHz
LINK1-LINK3 = SPC02SXCN-RC: 2 C, Open Top, .100" CC; No Mounting, 105 C, PBT; Phos Bronze, Gold Flash; Manufacturer: Sullins; Category: Connectors; ComponentLink1Description: https://datasheet.ciiva.com/767/285-sxcn-11508-767873.pdf?src-supplier=Digikey; ComponentLink1URL: https://datasheet.ciiva.com/767/285-sxcn-11508-767873.pdf?src-supplier=Digikey; Library Name: Schlib1.SchLib; Manufacturer Part Number: SPC02SXCN-RC; Pricing 1: 1=0.1, 10=0.059, 25=0.0544, 50=0.0512, 100=0.0481, 250=0.04432, 500=0.04168, 1000=0.03919, 3000=0.03555 (USD); RoHS: Yes; Stock 1: 37862; Supplier 1: Digikey; Supplier Part Number 1: S9342-ND
MH1-MH3 = MH_7.4MMC_3.2MMD: mounting hall 7.4mm diameter 3.2mm drill; Library Name: Schlib1.SchLib
MH4 = MH_7.4MMNC_3.2MMD: hole 7.4 mm diameter (non copper) 3.2mm drill npth; Library Name: Schlib1.SchLib
R1 = RC0805FR-07100KL: SMD Chip Resistor, 100 kOhm, ï¿½ 1%, 125 mW, 0805 [2012 Metric], Thick Film, General Purpose; Manufacturer: Yageo; Max Power Dissipation: 125mW; Temperature Coefficient: 100ppm/°C; Value: 100kR; Voltage Rating: 150V; Case Code (Imperial): 0805; Case Code (Metric): 2012; Case/Package: 0805; Category: Chip SMD Resistors; Component Kind: Standard; ComponentLink1Description: https://datasheet.ciiva.com/11808/0900766b8106beab-11808858.pdf?src-supplier=Rs; ComponentLink1URL: https://datasheet.ciiva.com/11808/0900766b8106beab-11808858.pdf?src-supplier=Rs; ComponentLink2Description: https://datasheet.ciiva.com/7941/1686128-7941677.pdf?src-supplier=Element14; ComponentLink2URL: https://datasheet.ciiva.com/7941/1686128-7941677.pdf?src-supplier=Element14; ComponentLink3Description: https://datasheet.ciiva.com/7660/38627-7660796.pdf?src-supplier=Element14; ComponentLink3URL: https://datasheet.ciiva.com/7660/38627-7660796.pdf?src-supplier=Element14; ComponentLink4Description: https://datasheet.ciiva.com/22747/444164-22747534.pdf?src-supplier=Element14; ComponentLink4URL: https://datasheet.ciiva.com/22747/444164-22747534.pdf?src-supplier=Element14; ComponentLink5Description: https://datasheet.ciiva.com/2457/pyu-r-int-thick-7-2457018.pdf?src-supplier=Digikey; ComponentLink5URL: https://datasheet.ciiva.com/2457/pyu-r-int-thick-7-2457018.pdf?src-supplier=Digikey; ComponentLink6Description: https://datasheet.ciiva.com/2457/pyu-r-int-thick-7-2457019.pdf?src-supplier=Digikey; ComponentLink6URL: https://datasheet.ciiva.com/2457/pyu-r-int-thick-7-2457019.pdf?src-supplier=Digikey; ComponentLink7Description: https://datasheet.ciiva.com/2457/pyu-r-int-thick-7-2457017.pdf?src-supplier=Digikey; ComponentLink7URL: https://datasheet.ciiva.com/2457/pyu-r-int-thick-7-2457017.pdf?src-supplier=Digikey; ComponentLink8Description: https://datasheet.ciiva.com/12667/rc0805-12667001.pdf?src-supplier=Mouser; ComponentLink8URL: https://datasheet.ciiva.com/12667/rc0805-12667001.pdf?src-supplier=Mouser; ComponentLink9Description: https://datasheet.ciiva.com/7941/1697719-7941676.pdf?src-supplier=Element14; ComponentLink9URL: https://datasheet.ciiva.com/7941/1697719-7941676.pdf?src-supplier=Element14; ComponentLink10Description: https://datasheet.ciiva.com/7941/1697719-7941678.pdf?src-supplier=Element14; ComponentLink10URL: https://datasheet.ciiva.com/7941/1697719-7941678.pdf?src-supplier=Element14; ComponentLink11Description: https://datasheet.ciiva.com/16747/rc0805-16747226.pdf?src-supplier=Future+Electronics; ComponentLink11URL: https://datasheet.ciiva.com/16747/rc0805-16747226.pdf?src-supplier=Future+Electronics; Construction: Rectangular; Depth: 1.25mm; Features: Moisture Resistant; Height: 0.024inch; Lead Free: 5697LeadFree; Lead Pitch: 0.4mm; Length: 0.079inch; Library Name: Schlib1.SchLib; Manufacturer Part Number: RC0805FR-07100KL; Max Operating Temperature: 155°C; Military Standard: Not; Min Operating Temperature: -55°C; Number of Terminations: 2; Package Quantity: 1; Packaging: Tape and Reel; Pins: 2; Power Rating: 125mW; Pricing 1: 5000=0.0017 (USD); Reach SVHC Compliant: Unknown; Resistance Tolerance: 1%; Resistor Type: Thick Film; RoHS: Yes; RoHS Compliant: Yes; Series: RC; Stock 1: 3175000; Supplier 1: Future Electronics; Supplier Part Number 1: 2952521; Technology: THICK FILM; Termination: SMD/SMT; Tolerance: 1%; Voltage Rating (AC): 150V; Voltage Rating (DC): 150V; Width: 0.049inch
R2 R3 R15 R17 R22 = RC0603FR-07560RL: SMD Chip Resistor, 560 Ohm, ï¿½ 1%, 100 mW, 0603 [1608 Metric], Thick Film, General Purpose; Manufacturer: Yageo; Max Power Dissipation: 100mW; Temperature Coefficient: 100ppm/°C; Value: 560R; Voltage Rating: 75V; Voltage Rating (AC): 50V; Case Code (Imperial): 0603; Case Code (Metric): 1608; Case/Package: 0603; Category: Chip SMD Resistors; Component Kind: Standard; ComponentLink1Description: https://datasheet.ciiva.com/11808/0900766b80f96399-11808127.pdf?src-supplier=Rs; ComponentLink1URL: https://datasheet.ciiva.com/11808/0900766b80f96399-11808127.pdf?src-supplier=Rs; ComponentLink2Description: https://datasheet.ciiva.com/46412/443971-46412853.pdf?src-supplier=Element14; ComponentLink2URL: https://datasheet.ciiva.com/46412/443971-46412853.pdf?src-supplier=Element14; ComponentLink3Description: https://datasheet.ciiva.com/2454/pyu-r-int-thick-7-2454382.pdf?src-supplier=Digikey; ComponentLink3URL: https://datasheet.ciiva.com/2454/pyu-r-int-thick-7-2454382.pdf?src-supplier=Digikey; ComponentLink4Description: https://datasheet.ciiva.com/2454/pyu-r-int-thick-7-2454383.pdf?src-supplier=Digikey; ComponentLink4URL: https://datasheet.ciiva.com/2454/pyu-r-int-thick-7-2454383.pdf?src-supplier=Digikey; ComponentLink5Description: https://datasheet.ciiva.com/2454/pyu-r-int-thick-7-2454381.pdf?src-supplier=Digikey; ComponentLink5URL: https://datasheet.ciiva.com/2454/pyu-r-int-thick-7-2454381.pdf?src-supplier=Digikey; ComponentLink6Description: https://datasheet.ciiva.com/7746/443971-7746374.pdf?src-supplier=Element14; ComponentLink6URL: https://datasheet.ciiva.com/7746/443971-7746374.pdf?src-supplier=Element14; ComponentLink7Description: https://datasheet.ciiva.com/7746/443971-7746375.pdf?src-supplier=Element14; ComponentLink7URL: https://datasheet.ciiva.com/7746/443971-7746375.pdf?src-supplier=Element14; Construction: Rectangular; Depth: 0.8mm; Features: Moisture Resistant; Height: 0.45mm; Lead Free: Lead Free; Length: 1.6mm; Library Name: Schlib1.SchLib; Manufacturer Part Number: RC0603FR-07560RL; Max Operating Temperature: 155°C; Military Standard: Not; Min Operating Temperature: -55°C; Number of Terminations: 2; Package Quantity: 1; Packaging: Tape and Reel; Pins: 2; Power Rating: 100mW; Pricing 1: 5000=0.001 (USD); Reach SVHC Compliant: No; Resistance Tolerance: 1%; Resistor Type: Thick Film; RoHS: Yes; RoHS Compliant: Yes; Series: RC; Stock 1: 335000; Supplier 1: Future Electronics; Supplier Part Number 1: 3410891; Technology: THICK FILM; Termination: SMD/SMT; Tolerance: 1%; Voltage Rating (DC): 50V; Weight: 7.1E-05oz; Width: 0.8mm
R4 R14 R18-R21 = RT0805FRE0710KL: Fixed Resistor, Thin Film, 0.125W, 10000ohm, 150V, 1% +/-Tol, 50ppm/Cel, Surface Mount, 0805; Manufacturer: Yageo; Category: Chip SMD Resistors; Component Kind: Standard; ComponentLink1Description: https://datasheet.ciiva.com/12834/pyu-rt-1-to-0-05-rohs-l-4-12834887.pdf?src-supplier=Mouser; ComponentLink1URL: https://datasheet.ciiva.com/12834/pyu-rt-1-to-0-05-rohs-l-4-12834887.pdf?src-supplier=Mouser; ComponentLink2Description: https://datasheet.ciiva.com/7942/1392914-7942071.pdf?src-supplier=Element14; ComponentLink2URL: https://datasheet.ciiva.com/7942/1392914-7942071.pdf?src-supplier=Element14; ComponentLink3Description: https://datasheet.ciiva.com/7942/1392914-7942072.pdf?src-supplier=Element14; ComponentLink3URL: https://datasheet.ciiva.com/7942/1392914-7942072.pdf?src-supplier=Element14; ComponentLink4Description: https://datasheet.ciiva.com/25647/pyu-rt-1-to-0-05-rohs-l-4-25647309.pdf?src-supplier=Digikey; ComponentLink4URL: https://datasheet.ciiva.com/25647/pyu-rt-1-to-0-05-rohs-l-4-25647309.pdf?src-supplier=Digikey; ComponentLink5Description: https://datasheet.ciiva.com/29711/pyu-rt-1-to-0-05-rohs-l-4-29711644.pdf?src-supplier=Digikey; ComponentLink5URL: https://datasheet.ciiva.com/29711/pyu-rt-1-to-0-05-rohs-l-4-29711644.pdf?src-supplier=Digikey; ComponentLink6Description: https://datasheet.ciiva.com/29711/pyu-rt-1-to-0-05-rohs-l-4-29711645.pdf?src-supplier=Digikey; ComponentLink6URL: https://datasheet.ciiva.com/29711/pyu-rt-1-to-0-05-rohs-l-4-29711645.pdf?src-supplier=Digikey; Lead Free: 5697LeadFree; Library Name: Schlib1.SchLib; Manufacturer Part Number: RT0805FRE0710KL; Pricing 1: 1=0.1, 10=0.029, 50=0.0244, 100=0.0204, 500=0.0199, 1000=0.01938 (USD); RoHS: Yes; Stock 1: 59694; Supplier 1: Digikey; Supplier Part Number 1: YAG1239CT-ND; Voltage Rating (DC): 150V
R5-R7 R13 R23-R25 = RC0402FR-0720RL: SMD Chip Resistor, 20 Ohm, ± 1%, 62.5 mW, 0402 [1005 Metric], Thick Film, General Purpose; Manufacturer: Yageo; Max Power Dissipation: 63mW; Power Rating: 62.5mW; Temperature Coefficient: 100ppm/°C; Value: 20R; Voltage Rating: 50V; Case Code (Imperial): 0402; Case Code (Metric): 1005; Case/Package: 0402; Category: Chip SMD Resistors; Component Kind: Standard; ComponentLink1Description: https://datasheet.ciiva.com/5998/1018594-5998583.pdf?src-supplier=Farnell; ComponentLink1URL: https://datasheet.ciiva.com/5998/1018594-5998583.pdf?src-supplier=Farnell; ComponentLink2Description: https://datasheet.ciiva.com/7849/1018594-7849902.pdf?src-supplier=Element14; ComponentLink2URL: https://datasheet.ciiva.com/7849/1018594-7849902.pdf?src-supplier=Element14; ComponentLink3Description: https://datasheet.ciiva.com/5998/1018594-5998584.pdf?src-supplier=Farnell; ComponentLink3URL: https://datasheet.ciiva.com/5998/1018594-5998584.pdf?src-supplier=Farnell; ComponentLink4Description: https://datasheet.ciiva.com/7849/1018594-7849903.pdf?src-supplier=Element14; ComponentLink4URL: https://datasheet.ciiva.com/7849/1018594-7849903.pdf?src-supplier=Element14; ComponentLink5Description: https://datasheet.ciiva.com/7983/1018594-7983513.pdf?src-supplier=Element14; ComponentLink5URL: https://datasheet.ciiva.com/7983/1018594-7983513.pdf?src-supplier=Element14; ComponentLink6Description: https://datasheet.ciiva.com/8890/pyu-rc0402-51-rohs-l-6-8890328.pdf?src-supplier=Avnet; ComponentLink6URL: https://datasheet.ciiva.com/8890/pyu-rc0402-51-rohs-l-6-8890328.pdf?src-supplier=Avnet; ComponentLink7Description: https://datasheet.ciiva.com/16741/pyu-rc0402-51-rohs-l-6-r-16741885.pdf?src-supplier=Future+Electronics; ComponentLink7URL: https://datasheet.ciiva.com/16741/pyu-rc0402-51-rohs-l-6-r-16741885.pdf?src-supplier=Future+Electronics; Construction: Rectangular; Contact Plating: Tin,Matte; Depth: 0.5mm; Features: Moisture Resistant; Height: 0.35mm; Lead Free: Lead Free; Length: 1mm; Library Name: Schlib1.SchLib; Manufacturer Part Number: RC0402FR-0720RL; Max Operating Temperature: 155°C; Military Standard: Not; Min Operating Temperature: -55°C; Number of Terminations: 2; Packaging: Tape and Reel; Pins: 2; Pricing 1: 10000=0.0006 (USD); Reach SVHC Compliant: No; Resistance Tolerance: 1%; Resistor Type: Thick Film; RoHS: Yes; RoHS Compliant: Yes; Series: RC; Stock 1: 620000; Supplier 1: Future Electronics; Supplier Part Number 1: 8738049; Technology: THICK FILM; Termination: SMD/SMT; Tolerance: 1%; Voltage Rating (DC): 50V; Width: 0.5mm; Working Voltage: 50V
R8 R26-R28 = RC0603JR-070RL: GENERAL PURPOSE CHIP RESISTOR Metal Glaze/thick Film, 0.1W, 0ohm, Surface Mount, 0603; Manufacturer: Yageo; Max Power Dissipation: 100mW; Resistance Tolerance: 5%; Temperature Coefficient: 200ppm/°C; Tolerance: 1%; Value: 0mR; Voltage Rating: 50V; Case Code (Imperial): 0603; Case Code (Metric): 1608; Case/Package: 0603; Category: Chip SMD Resistors; Component Kind: Standard; Construction: Rectangular; Contact Plating: Tin,Matte; Depth: 0.8mm; Features: Moisture Resistant; Height: 0.45mm; Lead Free: 5697LeadFree; Length: 1.6mm; Library Name: Schlib1.SchLib; Manufacturer Part Number: RC0603JR-070RL; Max Operating Temperature: 155°C; Military Standard: Not; Min Operating Temperature: -55°C; Number of Terminations: 2; Package Quantity: 5000; Packaging: Tape and Reel; Pins: 2; Power Rating: 100mW; Pricing 1: 5000=0.001 (USD); Reach SVHC Compliant: Unknown; Resistor Type: Thick Film; RoHS: Yes; RoHS Compliant: Yes; Series: RC; Stock 1: 7115000; Supplier 1: Future Electronics; Supplier Part Number 1: 3992146; Technology: THICK FILM; Termination: SMD/SMT; Voltage Rating (DC): 50V; Width: 0.8mm
R9 R16 = 26-61-4040: 3.96mm (.156") Pitch KK® Solid Header, Vertical, Friction Lock, 4 Circuits, Gold (Au) Plating; Manufacturer: Molex; Gender: 29Gender; Glow Wire Compliant: 16Compliance; Insulation Resistance: 50GR; Number of Circuits: 3NUMBER; Voltage Rating (DC): 250V; Category: Headers and Wire Housings; Component Kind: Standard; ComponentLink1Description: https://datasheet.ciiva.com/11749/0900766b80d6bf9b-11749077.pdf?src-supplier=Rs; ComponentLink1URL: https://datasheet.ciiva.com/11749/0900766b80d6bf9b-11749077.pdf?src-supplier=Rs; ComponentLink2Description: https://datasheet.ciiva.com/5158/0026614040-pcb-headers-159625-5158934.pdf?src-supplier=Mouser; ComponentLink2URL: https://datasheet.ciiva.com/5158/0026614040-pcb-headers-159625-5158934.pdf?src-supplier=Mouser; ComponentLink3Description: https://datasheet.ciiva.com/19462/880301-19462297.pdf?src-supplier=Jameco; ComponentLink3URL: https://datasheet.ciiva.com/19462/880301-19462297.pdf?src-supplier=Jameco; ComponentLink4Description: https://datasheet.ciiva.com/15217/getdatasheetpartid-308362-15217837.pdf?src-supplier=Verical; ComponentLink4URL: https://datasheet.ciiva.com/15217/getdatasheetpartid-308362-15217837.pdf?src-supplier=Verical; ComponentLink5Description: https://datasheet.ciiva.com/30222/217730026614040-pcb-headers-30222935.pdf?src-supplier=Onlinecomponents.com; ComponentLink5URL: https://datasheet.ciiva.com/30222/217730026614040-pcb-headers-30222935.pdf?src-supplier=Onlinecomponents.com; ComponentLink6Description: https://datasheet.ciiva.com/9468/0026614040-pcb-headers-9468314.pdf?src-supplier=Avnet; ComponentLink6URL: https://datasheet.ciiva.com/9468/0026614040-pcb-headers-9468314.pdf?src-supplier=Avnet; ComponentLink7Description: https://datasheet.ciiva.com/10029/0026614040-pcb-headers-10029276.pdf?src-supplier=Avnet; ComponentLink7URL: https://datasheet.ciiva.com/10029/0026614040-pcb-headers-10029276.pdf?src-supplier=Avnet; Flammability Rating: 5813Flammability; Lead Free: 5697LeadFree; Library Name: Schlib1.SchLib; Manufacturer Part Number: 26-61-4040; Pricing 1: 1=0.88, 10=0.75, 50=0.75, 100=0.67, 1000=0.649, 10000=0.617 (USD); RoHS: Yes; Stock 1: 5566; Supplier 1: Mouser; Supplier Part Number 1: 538-26-61-4040
R10-R12 = RC0603FR-071KL: SMD Chip Resistor, 1 kOhm, ï¿½ 1%, 100 mW, 0603 [1608 Metric], Thick Film, General Purpose; Manufacturer: Yageo; Max Power Dissipation: 100mW; Temperature Coefficient: 100ppm/°C; Value: 1kR; Voltage Rating: 50V; Case Code (Imperial): 0603; Case Code (Metric): 1608; Case/Package: 0603; Category: Chip SMD Resistors; Component Kind: Standard; Construction: Rectangular; Contact Plating: Tin,Matte; Depth: 0.8mm; Features: Moisture Resistant; Height: 0.45mm; Lead Free: 5697LeadFree; Length: 1.6mm; Library Name: Schlib1.SchLib; Manufacturer Part Number: RC0603FR-071KL; Max Operating Temperature: 155°C; Military Standard: Not; Min Operating Temperature: -55°C; Number of Terminations: 2; Packaging: Tape and Reel; Power Rating: 100mW; Pricing 1: 5000=0.001 (USD); Reach SVHC Compliant: No; Resistance Tolerance: 1%; Resistor Type: Thick Film; RoHS: Yes; RoHS Compliant: Yes; Series: RC; Stock 1: 11390000; Supplier 1: Future Electronics; Supplier Part Number 1: 4016291; Technology: THICK FILM; Termination: SMD/SMT; Tolerance: 1%
SCKT_FOR_U1 = 4828-3004-CP: Socket DIP 28Cnts DualWipe 0.3InSpc. PhosphorBronze Nickel KinkedTail 4800Series | 3M 4828-3004-CP; Category: Connectors; Component Kind: Standard; ComponentLink1Description: https://datasheet.ciiva.com/768/ts1099-768354.pdf?src-supplier=Digikey; ComponentLink1URL: https://datasheet.ciiva.com/768/ts1099-768354.pdf?src-supplier=Digikey; Library Name: Schlib1.SchLib; Manufacturer: 3M; Manufacturer Part Number: 4828-3004-CP; Pricing 1: 1=1.06, 14=0.89571, 28=0.85893, 56=0.84071, 112=0.80411, 252=0.73103, 504=0.65794, 1008=0.58483, 2506=0.53 (USD); RoHS: Yes; Stock 1: 11000; Supplier 1: Digikey; Supplier Part Number 1: 3M5480-ND
SW1 SW2 = 1825910-6: Alcoswitch, Switch, Pushbutton, Tactile, SPST, 50mA, Thru Hole, 6mm | TE Connectivity FSM4JH; Manufacturer: TE Connectivity Alcoswitch; Actuator Length: 0.055inch; Actuator Material: PBT; Actuator Type: Standard; Illumination Type: Non-Illuminated,Illuminated; Insulation Resistance: 100MR; Max Voltage Rating (DC): 24V; Mechanical Life: 100000Cycles; Number of Positions: 2NUMBER; Operating Force: 160gf; Switch Function: Off-Mom; Switch Travel: 0.25mm; Category: Pushbutton Switches; Color: 46Colour; Component Kind: Standard; Contact Current Rating: 50mA; Contact Material: Copper Alloy; Contact Plating: Silver; Current Rating: 50mA; ELV: Compliant; Lead Free: Lead Free; Length: 5.99mm; Library Name: Schlib1.SchLib; Manufacturer Part Number: 1825910-6; Material: 4261ConductorMaterial; Max Current Rating: 50mA; Max Operating Temperature: 85°C; Min Operating Temperature: -35°C; Mounting Technology: Through Hole; Packaging: Loose Piece; Pricing 1: 1=0.0525, 400=0.0511, 1250=0.0496, 2500=0.0487, 7500=0.0461 (USD); Reach SVHC Compliant: Unknown; RoHS: Yes; RoHS Compliant: Yes; Series: FSMJ; Stock 1: 4772; Supplier 1: Future Electronics; Supplier Part Number 1: 9026957; Termination: PC Pins; Throw Configuration: SPST; Type: Switch; Width: 6mm
u1 = ATMEGA328P-PU: Microchip - ATMEGA328P-PU - Microcontroller Mcu, 8 Bit, Atmega, 20MHZ, DIP-28; Core Architecture: AVR; Data Bus Width: 8b; Interface: I2C,SPI,USART,UART,2-Wire; Max Supply Voltage: 5.5V; Memory Format: FLASH; Memory Size: 32KB; Min Supply Voltage: 1.8V; Number of ADC Channels: 6; Number of I2C Channels: 1; Number of I/Os: 23; Number of Timers/Counters: 3; Oscillator Type: Internal; Peripherals: Brown-out Detect/Reset,POR,PWM,WDT; RAM Size: 2KB; Case/Package: PDIP; Category: Microcontrollers; Clock Frequency: 20MHz; Component Kind: Standard; ComponentLink1Description: https://datasheet.ciiva.com/19457/2139111-19457070.pdf?src-supplier=Jameco; ComponentLink1URL: https://datasheet.ciiva.com/19457/2139111-19457070.pdf?src-supplier=Jameco; ComponentLink2Description: https://datasheet.ciiva.com/1157/1684409-1157696.pdf?src-supplier=Element14; ComponentLink2URL: https://datasheet.ciiva.com/1157/1684409-1157696.pdf?src-supplier=Element14; ComponentLink3Description: https://datasheet.ciiva.com/29119/155197-da-01-en-microcontr-atmega328p-pu-8bit-pdip-28-29119593.pdf?src-supplier=Conrad; ComponentLink3URL: https://datasheet.ciiva.com/29119/155197-da-01-en-microcontr-atmega328p-pu-8bit-pdip-28-29119593.pdf?src-supplier=Conrad; ComponentLink4Description: https://datasheet.ciiva.com/15213/getdatasheetpartid-318533-15213133.pdf?src-supplier=Verical; ComponentLink4URL: https://datasheet.ciiva.com/15213/getdatasheetpartid-318533-15213133.pdf?src-supplier=Verical; Frequency: 20MHz; Height: 4.57mm; Lead Free: 5697LeadFree; Length: 34.8mm; Library Name: Schlib1.SchLib; Manufacturer: Microchip; Manufacturer Part Number: ATMEGA328P-PU; Max Operating Temperature: 85°C; Min Operating Temperature: -40°C; Mounting Technology: Through Hole; Number of PWM Channels: 6; Number of SPI Channels: 1; Package Quantity: 14; Packaging: Rail/Tube; Pins: 28; Pricing 1: 1=1.918, 112=1.899, 504=1.88 (USD); Radiation Hardening: No; Reach SVHC Compliant: No; RoHS: Yes; RoHS Compliant: Yes; Series: ATmega328P; Stock 1: 9130; Supplier 1: Arrow Electronics; Supplier Part Number 1: ATMEGA328P-PU; Weight: 0.077603oz; Width: 7.49mm
U2 = ATMEGA16U2-AUR: Risc Microcontroller, 8-BIT, Flash, AVR Risc Cpu, 16MHZ, Cmos, PQFP32; Manufacturer: Microchip; Interface: SPI,USB,UART,USART; Memory Size: 16KB; Number of PWM Channels: 4NUMBER; Number of SPI Channels: 1NUMBER; Oscillator Type: Internal; Peripherals: Brown-out Detect/Reset,POR,PWM,WDT; Case/Package: TQFP; Category: Microcontrollers; Component Kind: Standard; ComponentLink1Description: https://datasheet.ciiva.com/9234/doc7799-9234702.pdf?src-supplier=Avnet; ComponentLink1URL: https://datasheet.ciiva.com/9234/doc7799-9234702.pdf?src-supplier=Avnet; Core Architecture: AVR; Height: 1.2mm; Library Name: Schlib1.SchLib; Manufacturer Part Number: ATMEGA16U2-AUR; Max Operating Temperature: 85°C; Memory Format: FLASH; Min Operating Temperature: -40°C; Mounting Technology: Surface Mount; Number of UART Channels: 1NUMBER; Number of USB Channels: 1NUMBER; Packaging: Tape and Reel; Pricing 1: 1=3.34, 25=3.06, 100=2.75 (USD); RoHS: Yes; RoHS Compliant: Yes; Series: AVR® ATmega; Stock 1: 9596; Supplier 1: Digikey; Supplier Part Number 1: ATMEGA16U2-AURCT-ND
U3 = TL1963A-33DCQR: LDO Regulator Pos 3.3V 1.5A 6-Pin(5+Tab) SOT-223 T/R; Manufacturer: Texas Instruments; Accuracy: 3%; Dropout Voltage: 340mV; Input Bias Current: 1mA; Max Supply Voltage: 20V; Min Current Limit: 1.6A; Min Input Voltage: 2.1V; Number of Outputs: 1; Output Type: Fixed; Polarity: Positive; Case/Package: SOT-223-6; Category: Voltage Regulators - Linear; Component Kind: Standard; ComponentLink1Description: https://datasheet.ciiva.com/2046/tl1963a-2046162.pdf?src-supplier=Digikey; ComponentLink1URL: https://datasheet.ciiva.com/2046/tl1963a-2046162.pdf?src-supplier=Digikey; ComponentLink2Description: https://datasheet.ciiva.com/2046/tl1963a-2046161.pdf?src-supplier=Digikey; ComponentLink2URL: https://datasheet.ciiva.com/2046/tl1963a-2046161.pdf?src-supplier=Digikey; ComponentLink3Description: https://datasheet.ciiva.com/2046/tl1963a-2046163.pdf?src-supplier=Digikey; ComponentLink3URL: https://datasheet.ciiva.com/2046/tl1963a-2046163.pdf?src-supplier=Digikey; Lead Free: Lead Free; Library Name: Schlib1.SchLib; Manufacturer Part Number: TL1963A-33DCQR; Max Operating Temperature: 125°C; Max Output current: 1.5A; Max Output Voltage: 3.3V; Min Operating Temperature: -40°C; Min Output Voltage: 3.3V; Number of Regulators: 1; Output Current: 1.5A; Output Voltage: 3.3V; Package Quantity: 1; Packaging: Tape and Reel; Pins: 6; Pricing 1: 1=3.15, 10=2.25, 50=2.25, 100=2.02, 1000=1.83, 10000=1.61 (USD); Quiescent Current: 1mA; Radiation Hardening: No; RoHS: Yes; RoHS Compliant: Yes; Series: -; Stock 1: 4261; Supplier 1: Mouser; Supplier Part Number 1: 595-TL1963A-33DCQR
U4-U7 = SN74AHC1G09DBVR: 1-ch, 2-input 2-V to 5.5-V high-speed (9 ns) AND gate with open-drain outputs 5-SOT-23 -55 to 125; Manufacturer: Texas Instruments; Low Level Output Current: 8mA; Max Supply Voltage: 5.5V; Min Supply Voltage: 2V; Nominal Supply Current: 1uA; Number of Bits: 1; Number of Channels: 1NUMBER; Number of Inputs: 2; Output Current: 25mA; Propagation Delay: 7.5ns; Case/Package: SOT-23; Category: Logic Gates; Component Kind: Standard; ComponentLink1Description: https://datasheet.ciiva.com/1864/sn74ahc1g09-1864411.pdf?src-supplier=Digikey; ComponentLink1URL: https://datasheet.ciiva.com/1864/sn74ahc1g09-1864411.pdf?src-supplier=Digikey; ComponentLink2Description: https://datasheet.ciiva.com/1864/sn74ahc1g09-1864410.pdf?src-supplier=Digikey; ComponentLink2URL: https://datasheet.ciiva.com/1864/sn74ahc1g09-1864410.pdf?src-supplier=Digikey; ComponentLink3Description: https://datasheet.ciiva.com/1864/sn74ahc1g09-1864412.pdf?src-supplier=Digikey; ComponentLink3URL: https://datasheet.ciiva.com/1864/sn74ahc1g09-1864412.pdf?src-supplier=Digikey; Height: 1.45mm; Lead Free: Lead Free; Length: 2.9mm; Library Name: Schlib1.SchLib; Logic Function: AND GATE; Manufacturer Part Number: SN74AHC1G09DBVR; Max Operating Temperature: 125°C; Min Operating Temperature: -55°C; Mounting Technology: Surface Mount; Number of Circuits: 1; Number of Elements: 1; Number of Gates: 1; Number of Outputs: 1; Output Voltage: 5.5V; Package Quantity: 3000; Packaging: Tape and Reel; Pins: 5; Pricing 1: 1=0.12, 10=0.07, 50=0.07, 100=0.051, 1000=0.049, 10000=0.041 (USD); Quiescent Current: 1uA; Radiation Hardening: No; Reach SVHC Compliant: No; RoHS: Yes; RoHS Compliant: Yes; Series: 74AHC; Stock 1: 8478; Supplier 1: Mouser; Supplier Part Number 1: 595-SN74AHC1G09DBVR; Technology: CMOS; Thickness: 1.2mm; Width: 1.6mm
